# Altium schematic sheet Sheet3.SchDoc(Sheet3)
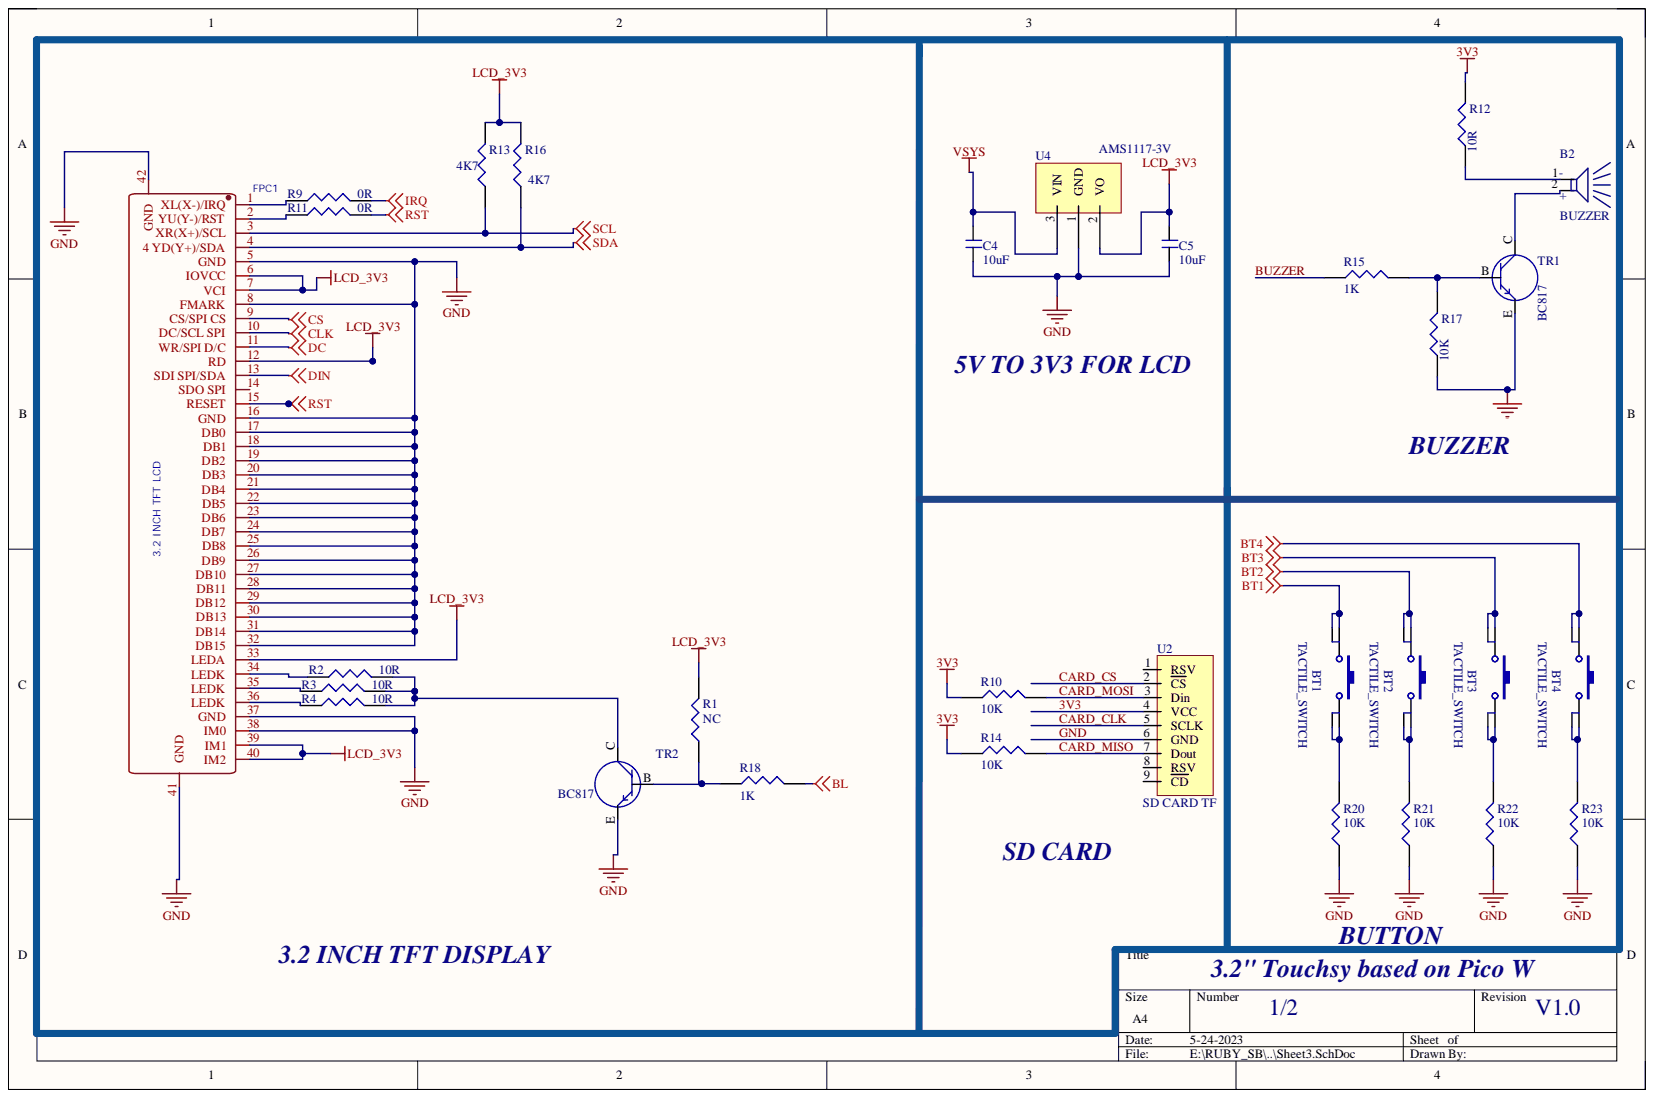
[diagram p0: Sheet3.SchDoc]

## Parts
B2  BUZZER  [SMD BUZZ]
BT1  TACTILE_SWITCH  [TACTILE]
BT2  TACTILE_SWITCH  [TACTILE]
BT3  TACTILE_SWITCH  [TACTILE]
BT4  TACTILE_SWITCH  [TACTILE]
C4  10uF  [C_0603]
C5  10uF  [C_0603]
FPC1  3.2 INCH TFT LCD  [3.2 TFT]
R1  NC  [R_0603]
R2  10R  [R_0603]
R3  10R  [R_0603]
R4  10R  [R_0603]
R9  0R  [R_0603]
R10  10K  [R_0603]
R11  0R  [R_0603]
R12  10R  [R_0603]
R13  4K7  [R_0603]
R14  10K  [R_0603]
R15  1K  [R_0603]
R16  4K7  [R_0603]
R17  10K  [R_0603]
R18  1K  [R_0603]
R20  10K  [R_0603]
R21  10K  [R_0603]
R22  10K  [R_0603]
R23  10K  [R_0603]
TR1  BC817  [SOT23]
TR2  BC817  [SOT23]
U2  SD CARD TF  [micro sd card]
U4  AMS1117-3V  [AMS1117_3V3]

## Nets
BL: R18.1
BT1: BT1.1 BT1.2
BT2: BT2.1 BT2.2
BT3: BT3.1 BT3.2
BT4: BT4.1 BT4.2
CLK: FPC1.10(DC/SCL_SPI)
CS: FPC1.9(CS/SPI_CS)
DC: FPC1.11(WR/SPI_D/C)
DIN: FPC1.13(SDI_SPI/SDA)
IRQ: R9.2
LCD_3V3: C5.2 FPC1.6(IOVCC) FPC1.7(VCI) FPC1.12(RD) FPC1.33(LEDA) FPC1.39(IM1) FPC1.40(IM2) R1.1 R13.2 R16.2 U4.2
RST: FPC1.15(RESET) R11.2
SCL: FPC1.3(XR(X+)/SCL) R13.1
SDA: FPC1.4(4_YD(Y+)/SDA) R16.1
VSYS: C4.2 U4.3
3V3: R10.1 R12.2 R14.1 U2.4(VCC)
BUZZER: R15.1
CARD_CLK: U2.5(SCLK)
CARD_CS: U2.2(CS)
CARD_MISO: R14.2 U2.7(Dout)
CARD_MOSI: R10.2 U2.3(Din)
GND: C4.1 C5.1 FPC1.5(GND) FPC1.8(FMARK) FPC1.16(GND) FPC1.17(DB0) FPC1.18(DB1) FPC1.19(DB2) FPC1.20(DB3) FPC1.21(DB4) FPC1.22(DB5) FPC1.23(DB6) FPC1.24(DB7) FPC1.25(DB8) FPC1.26(DB9) FPC1.27(DB10) FPC1.28(DB11) FPC1.29(DB12) FPC1.30(DB13) FPC1.31(DB14) FPC1.32(DB15) FPC1.37(GND) FPC1.38(IM0) FPC1.41(GND) FPC1.42(GND) R17.1 R20.1 R21.1 R22.1 R23.1 TR1.E TR2.E U2.6(GND) U4.1
NetB2_1: B2.1 R12.1
NetB2_2: B2.2 TR1.C
NetBT1_3: BT1.3 BT1.4 R20.2
NetBT2_3: BT2.3 BT2.4 R21.2
NetBT3_3: BT3.3 BT3.4 R22.2
NetBT4_3: BT4.3 BT4.4 R23.2
NetFPC1_1: FPC1.1(XL(X-)/IRQ) R9.1
NetFPC1_2: FPC1.2(YU(Y-)/RST) R11.1
NetFPC1_34: FPC1.34(LEDK) R2.1
NetFPC1_35: FPC1.35(LEDK) R3.1
NetFPC1_36: FPC1.36(LEDK) R4.1
NetR1_2: R1.2 R18.2 TR2.B
NetR2_2: R2.2 R3.2 R4.2 TR2.C
NetR15_2: R15.2 R17.2 TR1.B

Not connected: FPC1 14(SDO_SPI); U2 1(RSV) 8(RSV) 9(CD)

## Library data of the parts
B2 = BUZZER PIEZO: Component Kind: Standard; Library Name: dxp_pasl_lib.IntLib
C4 C5 = CAP_NONPOLAR: Component Kind: Standard; Library Name: dxp_pasl_lib.IntLib
FPC1 = FPC-40P: Component Kind: Standard; Library Name: MIX.SchLib
R1-R4 R9-R18 R20-R23 = RES: Component Kind: Standard; Library Name: dxp_pasl_lib.IntLib
TR1 TR2 = TRANSISTER_NPN: Component Kind: Standard; Library Name: dxp_pasl_lib.IntLib
U2 = SD CARD TF: Micro SD Memory Card Socket; Component Kind: Standard; Library Name: dxp_pasl_lib.IntLib
U4 = AMS1117: Component Kind: Standard; Library Name: dxp_pasl_lib.IntLib
BT1-BT4 = TACTILE_SWITCH: Component Kind: Standard; Library Name: dxp_pasl_lib.IntLib
